# Altium schematic sheet Buck-Schematic.SchDoc(Buck-Schematic)
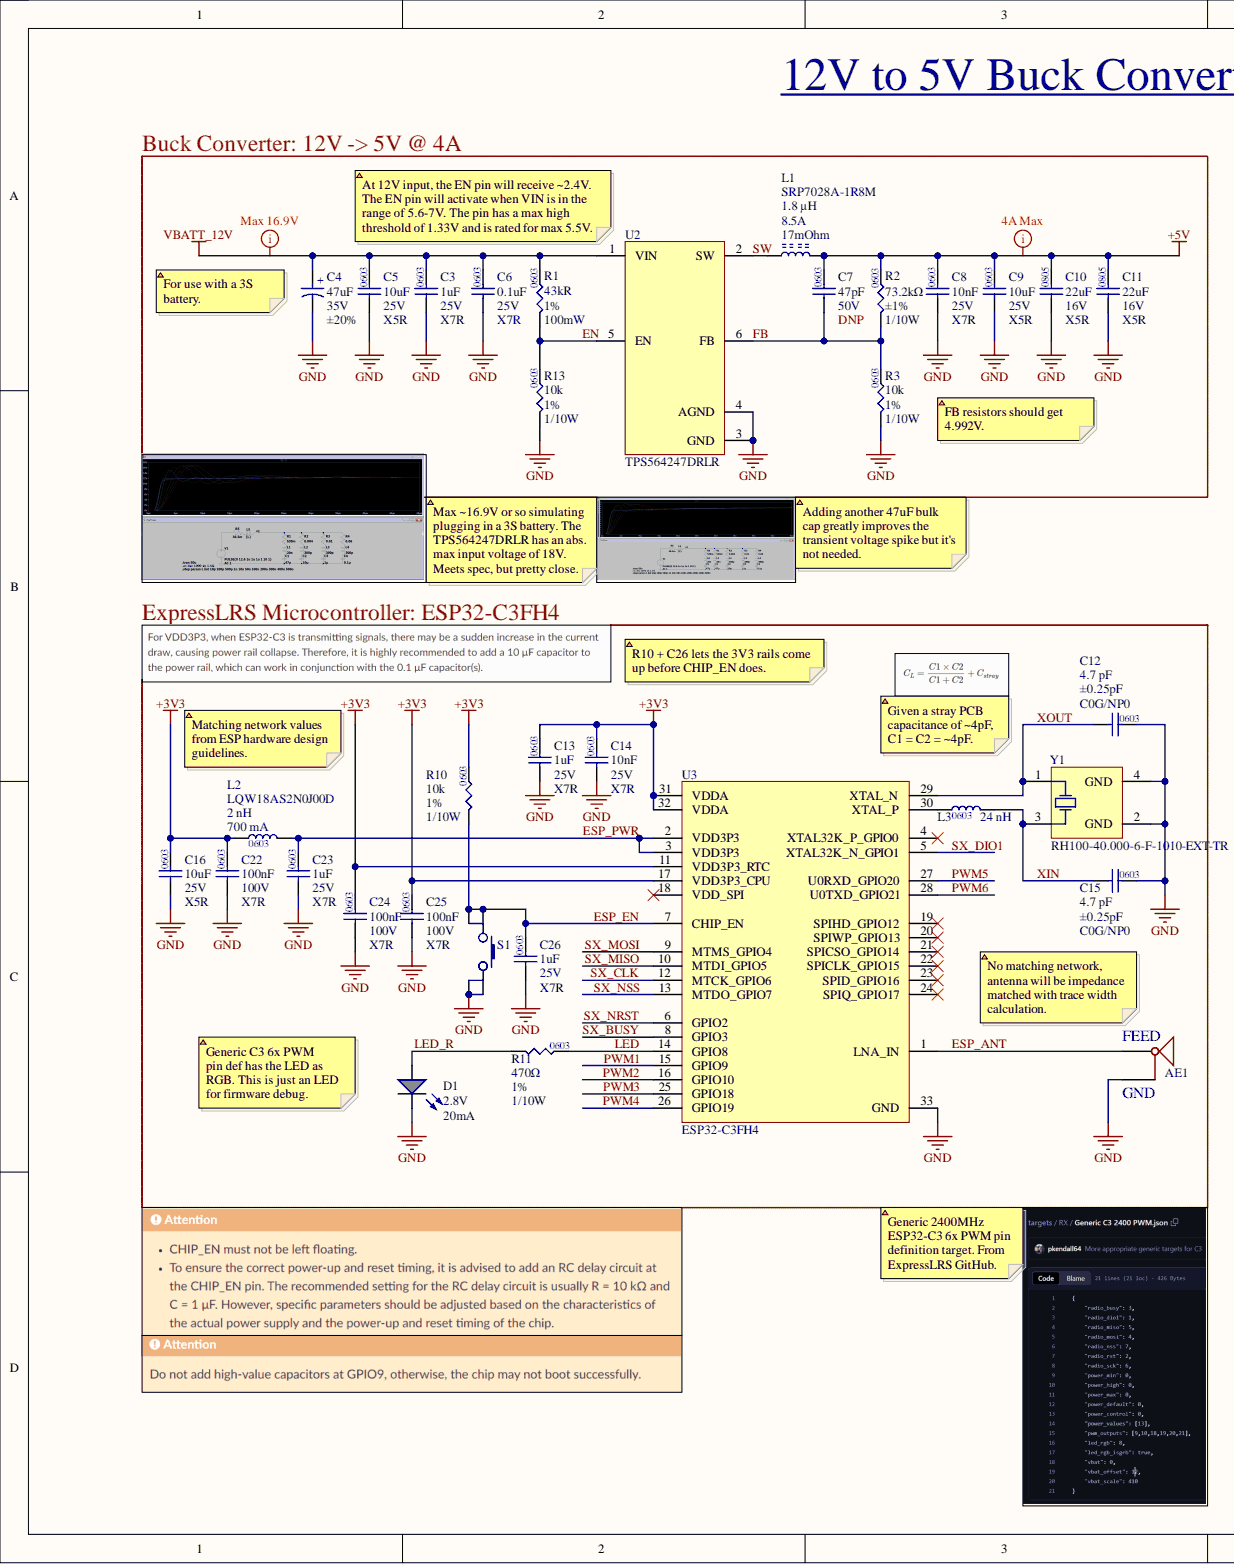
[diagram p0: Buck-Schematic.SchDoc, left]
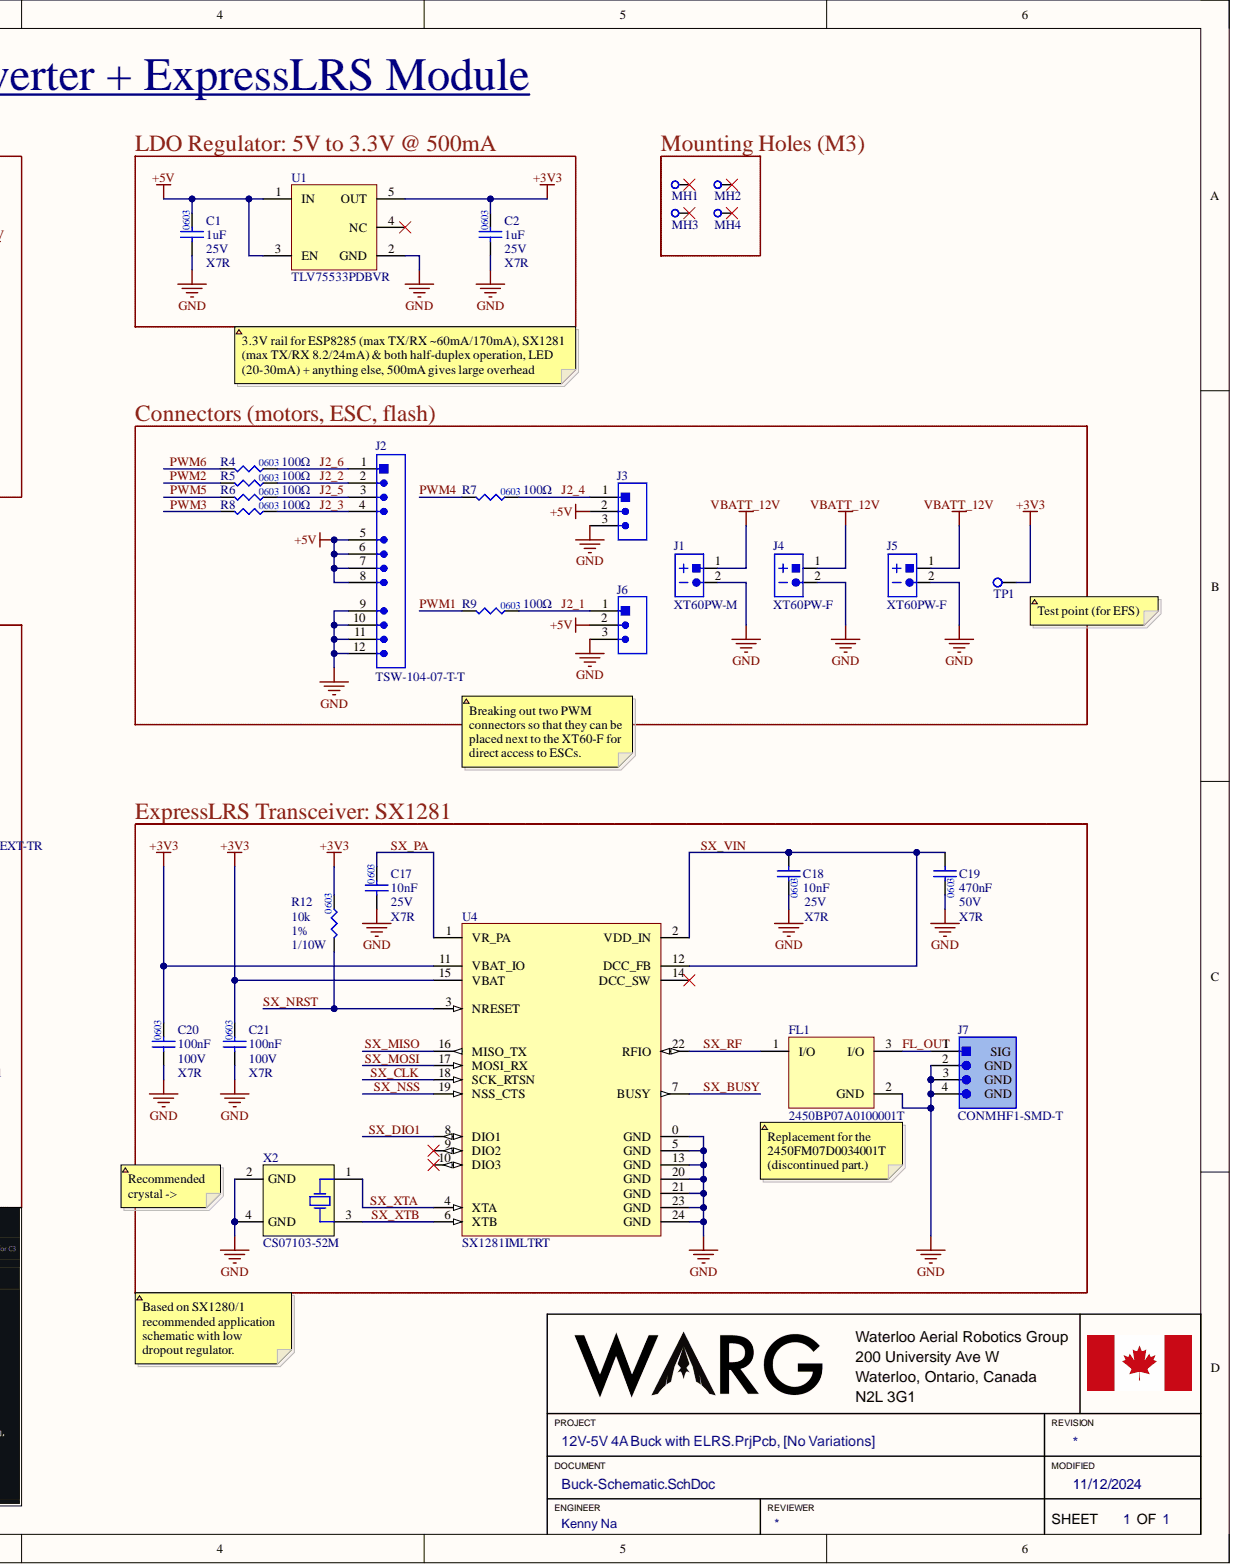
[diagram p1: Buck-Schematic.SchDoc, right]

## Parts
AE1  1461750001  (value not drawn)
C1  Capacitance: 1uF  Temperature Coefficient: X7R  Voltage Rating: 25V  (hidden comment: GCM188R71E105KA64D)
C2  Capacitance: 1uF  Temperature Coefficient: X7R  Voltage Rating: 25V  (hidden comment: GCM188R71E105KA64D)
C3  Capacitance: 1uF  Temperature Coefficient: X7R  Voltage Rating: 25V  (hidden comment: GCM188R71E105KA64D)
C4  Capacitance: 47uF  Tolerance: ±20%  Voltage: 35V  (hidden comment: 865080543009)
C5  value 10uF  Case/Package: 0603  Temperature Coefficient: X5R  Voltage Rating: 25V  (hidden comment: GRM188R61E106KA73J)
C6  value 0.1uF  Capacitance: 0.1uF  Package: 0603  Temperature Coefficient: X7R  Voltage Rating: 25V  (hidden comment: GCJ188R71E104KA12D)
C7  value 47pF  Case/Package: 0603  Voltage Rating: 50V  (hidden comment: CL10C470JB8NNNC)
C8  Capacitance: 10nF  Package: 0603  Temperature Coefficient: X7R  Voltage Rating: 25V  (hidden comment: CC0603KRX7R8BB103)
C9  value 10uF  Case/Package: 0603  Temperature Coefficient: X5R  Voltage Rating: 25V  (hidden comment: GRM188R61E106KA73J)
C10  value 22uF  Capacitance: 22uF  Package: 0805  Temperature Coefficient: X5R  Voltage: 16V  (hidden comment: CL21A226MOQNNNE)
C11  value 22uF  Capacitance: 22uF  Package: 0805  Temperature Coefficient: X5R  Voltage: 16V  (hidden comment: CL21A226MOQNNNE)
C12  Capacitance: 4.7 pF  Package / Case: 0603  Temperature Coefficient: C0G/NP0  Tolerance: ±0.25pF  (hidden comment: CC0603CRNPO9BN4R7)
C13  Capacitance: 1uF  Temperature Coefficient: X7R  Voltage Rating: 25V  (hidden comment: GCM188R71E105KA64D)
C14  Capacitance: 10nF  Package: 0603  Temperature Coefficient: X7R  Voltage Rating: 25V  (hidden comment: CC0603KRX7R8BB103)
C15  Capacitance: 4.7 pF  Package / Case: 0603  Temperature Coefficient: C0G/NP0  Tolerance: ±0.25pF  (hidden comment: CC0603CRNPO9BN4R7)
C16  value 10uF  Case/Package: 0603  Temperature Coefficient: X5R  Voltage Rating: 25V  (hidden comment: GRM188R61E106KA73J)
C17  Capacitance: 10nF  Package: 0603  Temperature Coefficient: X7R  Voltage Rating: 25V  (hidden comment: CC0603KRX7R8BB103)
C18  Capacitance: 10nF  Package: 0603  Temperature Coefficient: X7R  Voltage Rating: 25V  (hidden comment: CC0603KRX7R8BB103)
C19  Capacitance: 470nF  Case Code (Imperial): 0603  Dielectric: X7R  Voltage: 50V  (hidden comment: UMK107B7474KA-TR)
C20  value 100nF  Case/Package: 0603  Rated DC Voltage (URdc): 100V  Temperature Characteristics Code: X7R  (hidden comment: GRM188R72A104KA35J)
C21  value 100nF  Case/Package: 0603  Rated DC Voltage (URdc): 100V  Temperature Characteristics Code: X7R  (hidden comment: GRM188R72A104KA35J)
C22  value 100nF  Case/Package: 0603  Rated DC Voltage (URdc): 100V  Temperature Characteristics Code: X7R  (hidden comment: GRM188R72A104KA35J)
C23  Capacitance: 1uF  Temperature Coefficient: X7R  Voltage Rating: 25V  (hidden comment: GCM188R71E105KA64D)
C24  value 100nF  Case/Package: 0603  Rated DC Voltage (URdc): 100V  Temperature Characteristics Code: X7R  (hidden comment: GRM188R72A104KA35J)
C25  value 100nF  Case/Package: 0603  Rated DC Voltage (URdc): 100V  Temperature Characteristics Code: X7R  (hidden comment: GRM188R72A104KA35J)
C26  Capacitance: 1uF  Temperature Coefficient: X7R  Voltage Rating: 25V  (hidden comment: GCM188R71E105KA64D)
D1  Forward Current: 20mA  Forward Voltage: 2.8V  (hidden comment: LTST-C194TBKT)
FL1  2450BP07A0100001T
J1  XT60PW-M
J2  TSW-104-07-T-T
J3  61300311121  (value not drawn)
J4  XT60PW-F
J5  XT60PW-F
J6  61300311121  (value not drawn)
J7  CONMHF1-SMD-T
L1  SRP7028A-1R8M  Current Rating: 8.5A  DC Resistance: 17mOhm  Inductance: 1.8 µH
L2  LQW18AS2N0J00D  Current Rating: 700 mA  Inductance: 2 nH  Package / Case: 0603
L3  Inductance: 24 nH  Package / Case: 0603  (hidden comment: LQW18AN24NJ00D)
MH1  M3HOLE-STANDARD  (value not drawn)
MH2  M3HOLE-STANDARD  (value not drawn)
MH3  M3HOLE-STANDARD  (value not drawn)
MH4  M3HOLE-STANDARD  (value not drawn)
R1  value 43kR  Case/Package: 0603  Power: 100mW  Tolerance: 1%  (hidden comment: RC0603FR-0743KL)
R2  Package: 0603  Power: 1/10W  Resistance: 73.2kΩ  Tolerance: ±1%  (hidden comment: RC0603FR-0773K2L)
R3  value 10k  Package: 0603  Power: 1/10W  Resistance: 10k  Tolerance: 1%  (hidden comment: RC0603FR-0710KL)
R4  Package: 0603  Resistance: 100Ω  (hidden comment: RC0603FR-07100RL)
R5  Package: 0603  Resistance: 100Ω  (hidden comment: RC0603FR-07100RL)
R6  Package: 0603  Resistance: 100Ω  (hidden comment: RC0603FR-07100RL)
R7  Package: 0603  Resistance: 100Ω  (hidden comment: RC0603FR-07100RL)
R8  Package: 0603  Resistance: 100Ω  (hidden comment: RC0603FR-07100RL)
R9  Package: 0603  Resistance: 100Ω  (hidden comment: RC0603FR-07100RL)
R10  value 10k  Package: 0603  Power: 1/10W  Resistance: 10k  Tolerance: 1%  (hidden comment: RC0603FR-0710KL)
R11  value 470Ω  Package: 0603  Resistance: 470Ω  Tolerance: 1%  (hidden comment: CRGCQ0603F470R)
R12  value 10k  Package: 0603  Power: 1/10W  Resistance: 10k  Tolerance: 1%  (hidden comment: RC0603FR-0710KL)
R13  value 10k  Package: 0603  Power: 1/10W  Resistance: 10k  Tolerance: 1%  (hidden comment: RC0603FR-0710KL)
S1  PTS647SN38SMTR2LFS  (value not drawn)
TP1  TESTINGPOINT_1MM  (value not drawn)
U1  TLV75533PDBVR  Current: 500mA
U2  TPS564247DRLR
U3  ESP32-C3FH4
U4  SX1281IMLTRT
X2  CS07103-52M
Y1  RH100-40.000-6-F-1010-EXT-TR

## Nets
+3V3: C2.2 C13.2 C14.2 C16.2 C20.2 C21.2 C22.2 C24.2 C25.2 L2.1 R10.2 R12.2 TP1.1 U1.5(OUT) U3.11(VDD3P3_RTC) U3.17(VDD3P3_CPU) U3.31(VDDA) U3.32(VDDA) U4.11(VBAT_IO) U4.15(VBAT)
+5V: C1.2 C7.2 C8.2 C9.2 C10.2 C11.2 J2.5 J2.6 J2.7 J2.8 J3.2 J6.2 L1.2 R2.2 U1.1(IN) U1.3(EN)
GND: AE1.2 C1.1 C2.1 C3.1 C4.2 C5.1 C6.1 C8.1 C9.1 C10.1 C11.1 C12.2 C13.1 C14.1 C15.2 C16.1 C17.1 C18.2 C19.2 C20.1 C21.1 C22.1 C23.1 C24.1 C25.1 C26.1 D1.2 FL1.2 J1.2 J2.9 J2.10 J2.11 J2.12 J3.3 J4.2 J5.2 J6.3 J7.2(GND) J7.3(GND) J7.4(GND) R3.1 R13.1 S1.3 S1.4 U1.2(GND) U2.3(GND) U2.4(AGND) U3.33(GND) U4.0(GND) U4.5(GND) U4.13(GND) U4.20(GND) U4.21(GND) U4.23(GND) U4.24(GND) X2.2(GND) X2.4(GND) Y1.2(GND) Y1.4(GND)
VBATT_12V: C3.2 C4.1 C5.2 C6.2 J1.1 J4.1 J5.1 R1.2 U2.1(VIN)
EN: R1.1 R13.2 U2.5(EN)
ESP_ANT: AE1.1 U3.1(LNA_IN)
ESP_EN: C26.2 R10.1 S1.1 S1.2 U3.7(CHIP_EN)
ESP_PWR: C23.2 L2.2 U3.2(VDD3P3) U3.3(VDD3P3)
FB: C7.1 R2.1 R3.2 U2.6(FB)
FL_OUT: FL1.3 J7.1
J2_1: J6.1 R9.2
J2_2: J2.2 R5.2
J2_3: J2.4 R8.2
J2_4: J3.1 R7.2
J2_5: J2.3 R6.2
J2_6: J2.1 R4.2
LED: R11.2 U3.14(GPIO8)
LED_R: D1.1 R11.1
PWM1: R9.1 U3.15(GPIO9)
PWM2: R5.1 U3.16(GPIO10)
PWM3: R8.1 U3.25(GPIO18)
PWM4: R7.1 U3.26(GPIO19)
PWM5: R6.1 U3.27(U0RXD_GPIO20)
PWM6: R4.1 U3.28(U0TXD_GPIO21)
SW: L1.1 U2.2(SW)
SX_BUSY: U3.8(GPIO3) U4.7(BUSY)
SX_CLK: U3.12(MTCK_GPIO6) U4.18(SCK_RTSN)
SX_DIO1: U3.5(XTAL32K_N_GPIO1) U4.8(DIO1)
SX_MISO: U3.10(MTDI_GPIO5) U4.16(MISO_TX)
SX_MOSI: U3.9(MTMS_GPIO4) U4.17(MOSI_RX)
SX_NRST: R12.1 U3.6(GPIO2) U4.3(NRESET)
SX_NSS: U3.13(MTDO_GPIO7) U4.19(NSS_CTS)
SX_PA: C17.2 U4.1(VR_PA)
SX_RF: FL1.1 U4.22(RFIO)
SX_VIN: C18.1 C19.1 U4.2(VDD_IN) U4.12(DCC_FB)
SX_XTA: U4.4(XTA) X2.1
SX_XTB: U4.6(XTB) X2.3
XIN: C15.1 L3.2 Y1.3
XOUT: C12.1 U3.29(XTAL_N) Y1.1
NetL3_1: L3.1 U3.30(XTAL_P)

Not connected: MH1 1; MH2 1; MH3 1; MH4 1; U1 4(NC); U3 4(XTAL32K_P_GPIO0) 18(VDD_SPI) 19(SPIHD_GPIO12) 20(SPIWP_GPIO13) 21(SPICSO_GPIO14) 22(SPICLK_GPIO15) 23(SPID_GPIO16) 24(SPIQ_GPIO17); U4 9(DIO2) 10(DIO3) 14(DCC_SW)

## Library data of the parts
AE1 = CMP-00141-4: RF ANT 2.4GHZ/5.4GHZ CHIP SLD; Component Kind: Standard; Library Name: WARG
C1-C3 C13 C23 C26 = CMP-00240-2: CAP CER 1UF 25V X7R 0603; Tolerance: 10%; Component Kind: Standard; Mounting Technology: Surface Mount; Library Name: WARG
C4 = CMP-00362-5: CAP ALUM 47UF 20% 35V SMD; Impedance: 530 mOhms; Ripple Current @ High Frequency: 240 mA @ 100 kHz; Ripple Current @ Low Frequency: 156 mA @ 120 Hz; Component Kind: Standard; Library Name: WARG
C5 C9 C16 = CMP-00056-4: CAP CER 10UF 25V X5R 0603; Component Kind: Standard; Library Name: WARG
C6 = CMP-001-00036-3: CAP CER 0.1UF 25V X7R 0603; Component Kind: Standard; Library Name: WARG
C7 = CMP-00133-1: CAP CER 47PF ±5% 50V C0G/NP0 0603; Component Kind: Standard; Library Name: WARG
C8 C14 C17 C18 = CMP-00217-1: CAP CER 10nF 25V X7R 0603; Component Kind: Standard; Library Name: WARG
C10 C11 = CMP-001-00015-5: CAP CER 22UF 16V X5R 0805; Component Kind: Standard; Library Name: WARG
C12 C15 = CMP-00410-1: CAP CER 4.7PF 50V C0G/NPO 0603; Component Kind: Standard; Voltage: 50V; Library Name: WARG
C19 = CMP-00012-3: 0603 0.47 uF 50 V ±10% Tolerance X7R Multilayer Ceramic Capacitor; Case Code (Metric): 1608; Case/Package: 0603; Component Kind: Standard; Construction: Flat; Depth: 0.8mm; Halogen Free: Halogen Free; Height: 0.8mm; Lead Free: Lead Free; Length: 1.6mm; Max Operating Temperature: 125°C; Min Operating Temperature: -55°C; Mount: Surface Mount; Package Quantity: 1; Packaging: Tape and Reel; Radiation Hardening: No; RoHS Compliant: Yes; Series: M; Termination: SMD/SMT; Thickness: 0.035inch; Tolerance: 10%; Voltage Rating: 50V; Voltage Rating (DC): 50V; Width: 0.031inch; Library Name: WARG
C20-C22 C24 C25 = CMP-001-00050-5: CAP CER 0.1UF 100V X7R 0603; Capacitor Type: Ceramic; Multilayer: Yes; Tolerance  (fill in as +-): 10%; Component Kind: Standard; Dielectric Material: Ceramic; Height: 0.8mm; JESD-609 Code: e3; Length: 1.6mm; Max Operating Temperature: 125°C; Min Operating Temperature: -55°C; Mounting Technology: Surface Mount; Package Shape: Rectangular; Package Style: SMTMeter; Packaging: Tape and Reel; Pins: 2; Reach SVHC Compliant: Yes; RoHS Compliant: Yes; Terminal Finish: Nickel; Termination: Wraparound; Tolerance (fill in as +-): 10%; Width: 0.8mm; Library Name: WARG
D1 = CMP-00017-4: LED BLUE CLEAR 0603 SMD; Wavelength: 473nm; Color: Blue; Component Kind: Standard; Package: 0603; Library Name: WARG
FL1 = CMP-00398-3: RF FILTER BAND PASS 2.45GHZ 0402; Manufacturer 1: Johanson Technology Inc.; Bandwidth: 100MHz; Impedance: 50Ω; Insertion Loss: 2.5dB; Component Kind: Standard; Filter Type: Band Pass; Frequency: 2.45GHz; Package: 0402; Library Name: WARG
J1 = CMP-00007-11: Board Mount XT60PW-M 2 Pin Male Connector; Manufacturer: TME; Component Kind: Standard; Library Name: WARG
J2 = CMP-00354-3: CONN HEADER VERT 12POS 2.54MM; Manufacturer 1: Samtec Inc.; Component Kind: Standard; Contact Finish - Mating: MATTE TIN OVER NICKEL (50); Mating Pitch: 2.54mm; Terminal Length: 0.1inch; Library Name: WARG
J3 J6 = CMP-00111-4: CONN HEADER VERT 3POS 2.54MM; Connector Type: Male Pin; Pitch: 100mil; Positions: 3; Component Kind: Standard; Mount: Through Hole; Library Name: WARG
J4 J5 = CMP-00086-5: Board Mount XT60PW-F 2 Pin Female Connector; Manufacturer: TME; Component Kind: Standard; Library Name: WARG
J7 = CMP-00321-7: CONN UMC JACK STR SMD; Impedance: 50Ω; Max Frequency: 6GHz; Voltage Rating: 60V; Component Kind: Standard; Mounting Type: Surface Mount; Library Name: WARG
L1 = CMP-00342-6: FIXED IND 1.8UH 8.5A 17 MOHM SMD; Manufacturer 1: Bourns Inc.; Current Saturation: 16A; Tolerance: ±20%; Component Kind: Standard; Library Name: WARG
L2 = CMP-00411-1: FIXED IND 2.0NH 700MA 0603 5%; DC Resistance: 130mOhm Max; Frequency - Self Resonant: 12GHz; Inductance Frequency - Test: 250 MHz; Component Kind: Standard; Tolerance: ±5%; Library Name: WARG
L3 = CMP-00409-2: FIXED IND 24NH 500MA 0.21OHM SMD; DC Resistance: 210mOhm Max; Frequency - Self Resonant: 3.8GHz; Inductance Frequency - Test: 100 MHz; Tolerance: ±5%; Component Kind: Standard; Current Rating: 500 mA; Library Name: WARG
MH1-MH4 = CMP-00005-4: Standard M3 Mounting hole as defined by mech team, see confluence for reasonning; Hole Diam: 3.4; Plating Diam: 6; Component Kind: Standard; Library Name: WARG
R1 = CMP-00392-1: RES 43K OHM 1% 1/10W 0603; Additional Feature: LASER TRIMMABLE; Package Height: 0.45mm; Package Length: 1.6mm; Package Width: 0.8mm; Rated Temperature: 70°C; Temperature Coefficient: 100ppm/°C; Working Voltage: 75V; Component Kind: Standard; Construction: Chip; JESD-609 Code: e3; Max Operating Temperature: 155°C; Min Operating Temperature: -55°C; Mounting Technology: Surface Mount; Package Shape: Rectangular; Package Style: SMTMeter; Packaging: Tape and Reel; Pins: 2; Reach SVHC Compliant: Yes; Resistor Type: FIXED RESISTOR; RoHS Compliant: Yes; Technology: METAL GLAZE/THICK FILM; Terminal Finish: Nickel; Termination: Wraparound; Library Name: WARG
R2 = CMP-001-00057-4: RES 73.2K OHM 1% 1/10W 0603; Component Kind: Standard; Library Name: WARG
R3 R10 R12 R13 = CMP-009-00006-5: RES 10K OHM 1% 1/10W 0603; Component Kind: Standard; Library Name: WARG
R4-R9 = CMP-00022-4: RES 100 OHM 1% 1/10W 0603; Component Kind: Standard; Power: 1/10W; Tolerance: ±1%; Library Name: WARG
R11 = CMP-00370-2: RES 470 OHM 1% 1/10W 0603; Component Kind: Standard; Power: 1/10W; Library Name: WARG
S1 = CMP-00306-4: SWITCH TACTILE SPST-NO 0.05A 12V; Contact Rating @ Voltage: 0.05A @ 12VDC; Outline: 4.50mm x 4.50mm; Circuit: SPST-NO; Component Kind: Standard; Manufacturer Part Number: PTS647SN38SMTR2LFS; Operating Temperature Range: -20°C ~ 70°C; Library Name: WARG
TP1 = CMP-00028-00002-1: Testing Point 1mm Radius; Component Kind: Standard; Radius: 1mm; Library Name: WARG
U1 = CMP-00225-6: IC REG LINEAR 3.3V 500MA SOT23-5; Component Kind: Standard; Output Voltage: 3.3 V; Library Name: WARG
U2 = CMP-00320-6: IC REG BUCK ADJ 6A SOT563; Max Input Voltage: 16V; Max Output Voltage: 7V; Min Input Voltage: 3V; Min Output Voltage: 0.6V; Output Current: 4A; Switching Frequency: 1.2MHz; Case/Package: SOT-563; Component Kind: Standard; Mount: Surface Mount; Library Name: WARG
U3 = CMP-00408-4: RF TXRX MOD BLUETOOTH WIFISMD; Manufacturer: Espressif Systems; Current - Receiving: 84mA ~ 87mA; Current - Transmitting: 276mA ~ 335mA; Data Rate: 54Mbps; Frequency: 2.402GHz ~ 2.48GHz; Memory: 4MB; Protocol: 802.11b/g/n, Bluetooth v5.0; Voltage: 3-3.6V; Component Kind: Standard; ComponentLink1Description: Datasheet; ComponentLink1URL: https://www.espressif.com/sites/default/files/documentation/esp32-c3_datasheet_en.pdf; Package: 32-VFQFN; Library Name: WARG
U4 = CMP-001-0019-3: IC RF TXRX 802.15.4 24QFN; Component Kind: Standard; Mounting Type: SMD; Package Name: 24-WFQFN; Library Name: WARG
X2 = CMP-00324-2: CRYSTAL 52.0000MHZ 10PF SMD; Equivalent Series Resistance: 50 Ohms; Frequency: 52 MHz; Operating Mode: Fundamental; Capacitance: 10pF; Component Kind: Standard; Library Name: WARG
Y1 = CMP-00157-4: CRYSTAL 40.0000MHZ 6PF SMD; Equivalent Series Resistance: 50 Ohms; Frequency: 40 MHz; Frequency Stability: ±10ppm; Component Kind: Standard; Frequency Tolerance: ±10ppm; Load Capacitance: 6pF; Library Name: WARG
